# Altium schematic sheet CtDSP_IO.SchDoc
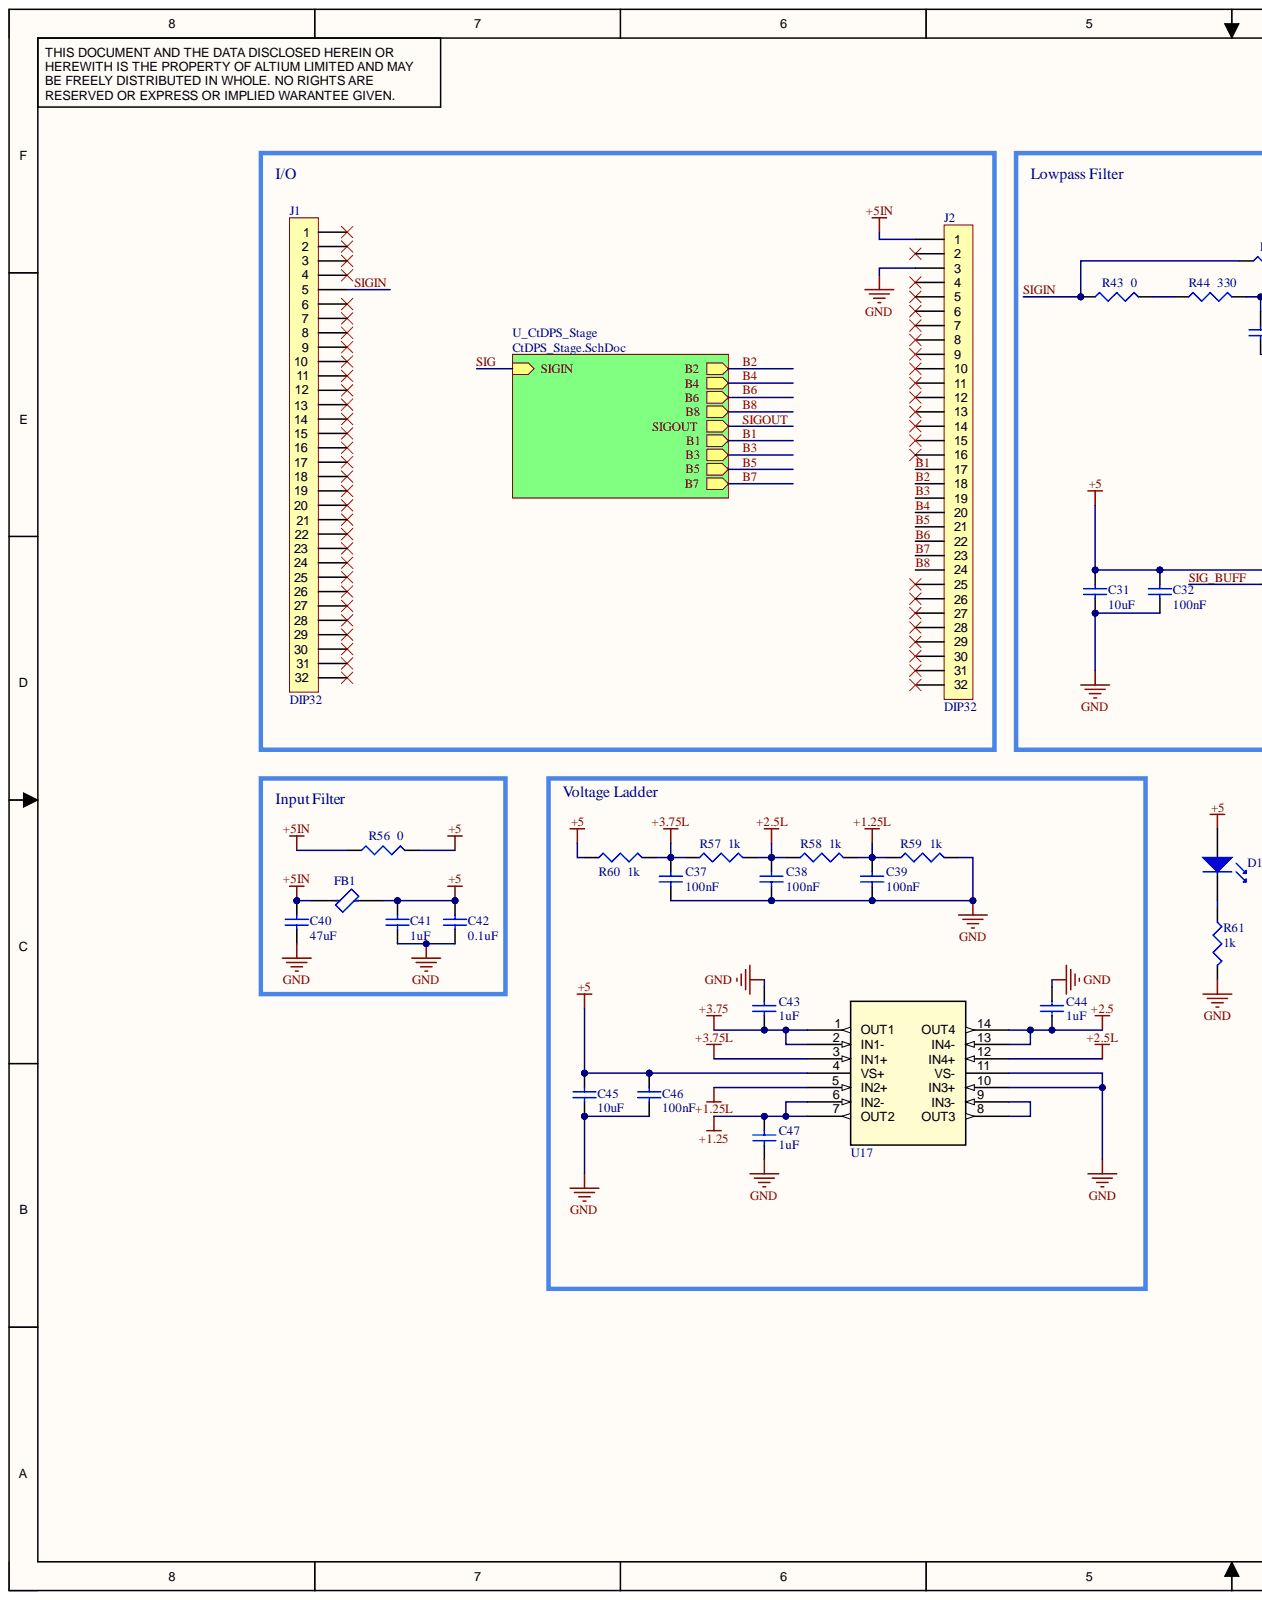
[diagram p0: CtDSP_IO.SchDoc, left]
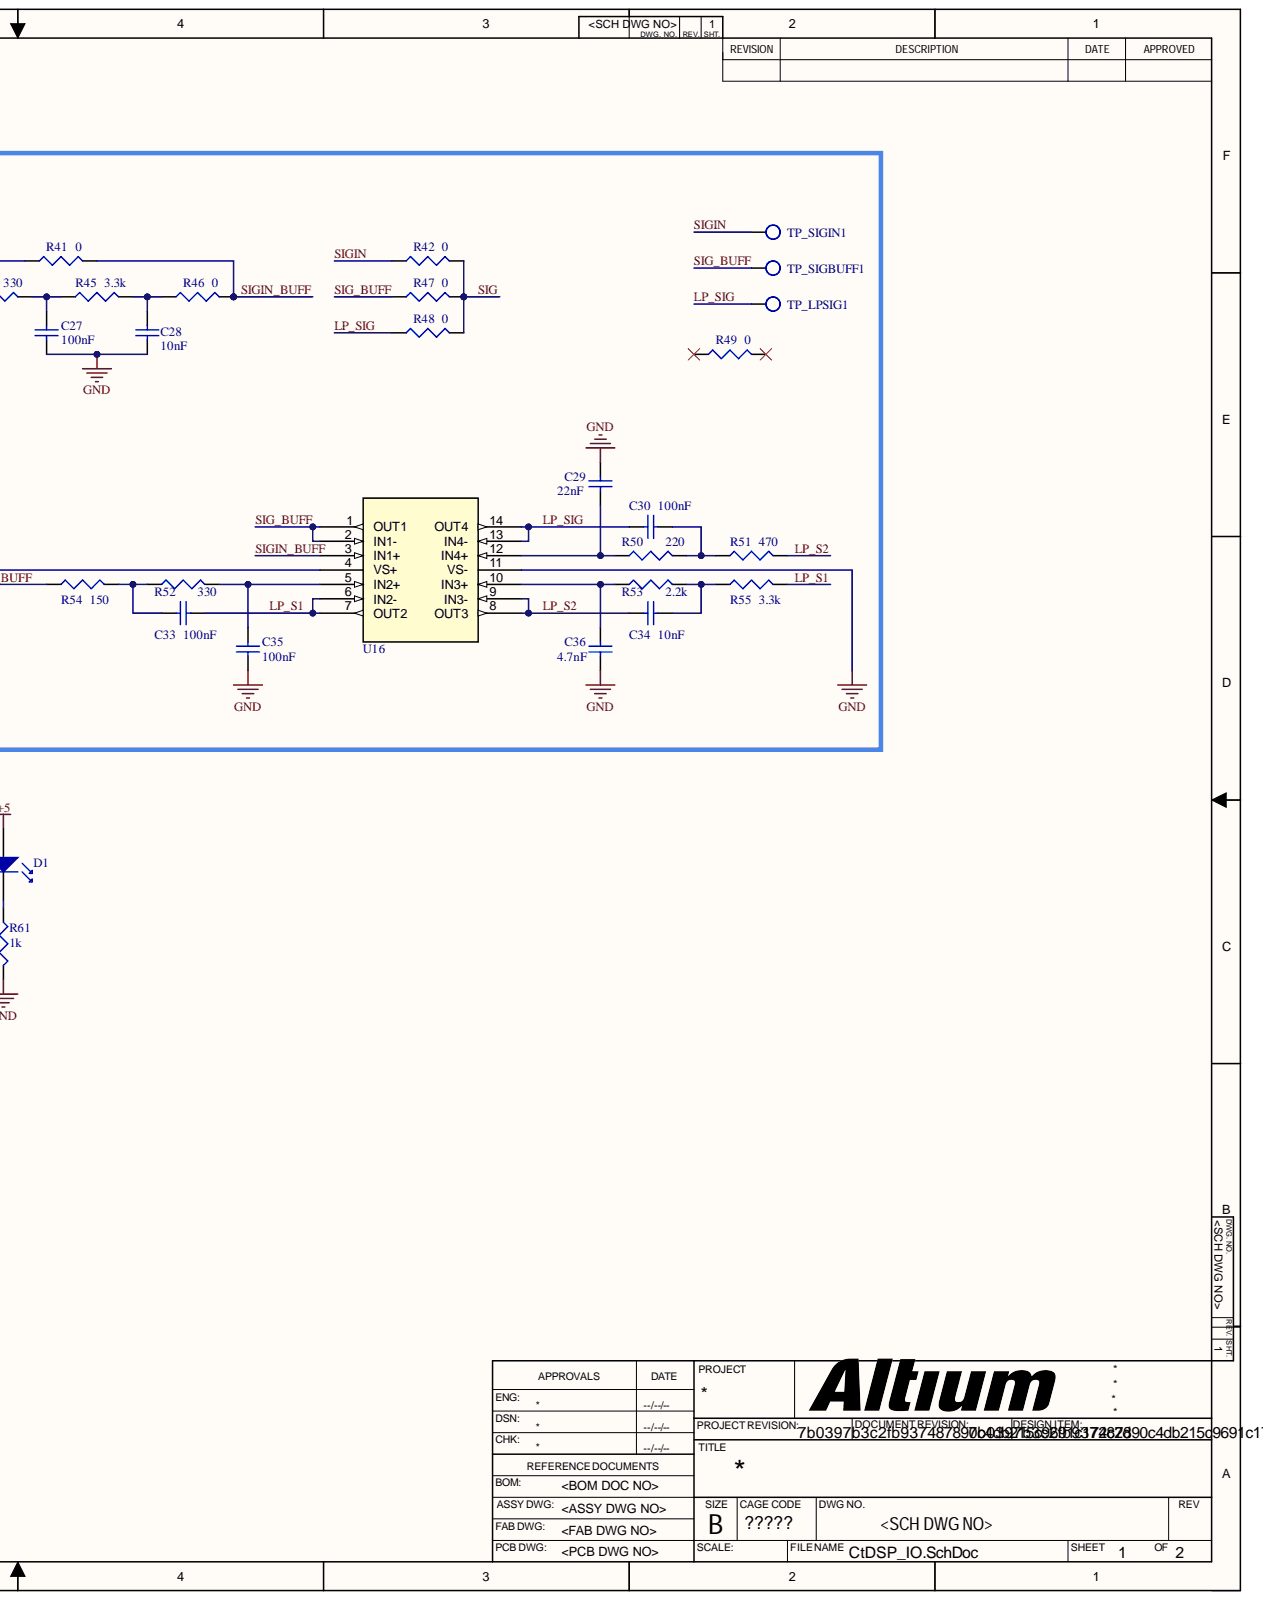
[diagram p1: CtDSP_IO.SchDoc, right]

## Parts
C27  100nF  [C_0402]
C28  10nF  [C_0402]
C29  22nF  [C_0402]
C30  100nF  [C_0402]
C31  10uF  [C_0402]
C32  100nF  [C_0402]
C33  100nF  [C_0402]
C34  10nF  [C_0402]
C35  100nF  [C_0402]
C36  4.7nF  [C_0402]
C37  100nF  [C_0402]
C38  100nF  [C_0402]
C39  100nF  [C_0402]
C40  47uF  [C_0805]
C41  1uF  [C_0603]
C42  0.1uF  [C_0402]
C43  1uF  [C_0603]
C44  1uF  [C_0603]
C45  10uF  [C_0402]
C46  100nF  [C_0402]
C47  1uF  [C_0603]
D1  LED_0603  [LED_6003]  (value not drawn)
FB1  BLM18PG121SN1D  [BLM18PG121SN1D]  (value not drawn)
J1  DIP32  [DIP32]
J2  DIP32  [DIP32]
R41  0  [RES_1206]
R42  0  [RES_1206]
R43  0  [RES_1206]
R44  330  [RES_0603]
R45  3.3k  [RES_0603]
R46  0  [RES_1206]
R47  0  [RES_1206]
R48  0  [RES_1206]
R49  0  [RES_1206]
R50  220  [RES_0402]
R51  470  [RES_0402]
R52  330  [RES_0603]
R53  2.2k  [RES_0603]
R54  150  [RES_0603]
R55  3.3k  [RES_0603]
R56  0  [RES_1206]
R57  1k  [RES_0603]
R58  1k  [RES_0603]
R59  1k  [RES_0603]
R60  1k  [RES_0603]
R61  1k  [RES_0402]
TP_LPSIG1  TESTPOINT  [TESTPOINT]  (value not drawn)
TP_SIGBUFF1  TESTPOINT  [TESTPOINT]  (value not drawn)
TP_SIGIN1  TESTPOINT  [TESTPOINT]  (value not drawn)
U16  ADA4891-4ARZ-R7  [ADA4891-4ARZ-R7]  (value not drawn)
U17  ADA4891-4ARZ-R7  [ADA4891-4ARZ-R7]  (value not drawn)

## Nets
+1.25: C47.2 U17.6(IN2-) U17.7(OUT2)
+1.25L: C39.2 R58.2 R59.1 U17.5(IN2+)
+2.5: C44.1 U17.13(IN4-) U17.14(OUT4)
+2.5L: C38.2 R57.2 R58.1 U17.12(IN4+)
+3.75: C43.1 U17.1(OUT1) U17.2(IN1-)
+3.75L: C37.2 R57.1 R60.2 U17.3(IN1+)
+5: C31.1 C32.2 C41.2 C42.1 C45.1 C46.2 D1.2 FB1.2 R56.2 R60.1 U16.4(VS+) U17.4(VS+)
+5IN: C40.2 FB1.1 J2.1 R56.1
GND: C27.1 C28.1 C29.2 C31.2 C32.1 C35.1 C36.1 C37.1 C38.1 C39.1 C40.1 C41.1 C42.2 C43.2 C44.2 C45.2 C46.1 C47.1 J2.3 R59.2 R61.2 U16.11(VS-) U17.10(IN3+) U17.11(VS-)
B1: J2.17
B2: J2.18
B3: J2.19
B4: J2.20
B5: J2.21
B6: J2.22
B7: J2.23
B8: J2.24
LP_S1: C33.1 R55.2 U16.6(IN2-) U16.7(OUT2)
LP_S2: C34.1 R51.2 U16.8(OUT3) U16.9(IN3-)
LP_SIG: C30.2 R48.1 TP_LPSIG1.1 U16.13(IN4-) U16.14(OUT4)
SIG: R42.2 R47.2 R48.2
SIG_BUFF: R47.1 R54.1 TP_SIGBUFF1.1 U16.1(OUT1) U16.2(IN1-)
SIGIN: J1.5 R41.1 R42.1 R43.1 TP_SIGIN1.1
SIGIN_BUFF: R41.2 R46.2 U16.3(IN1+)
NetC27_2: C27.2 R44.2 R45.1
NetC28_2: C28.2 R45.2 R46.1
NetC29_1: C29.1 R50.1 U16.12(IN4+)
NetC30_1: C30.1 R50.2 R51.1
NetC33_2: C33.2 R52.1 R54.2
NetC34_2: C34.2 R53.2 R55.1
NetC35_2: C35.2 R52.2 U16.5(IN2+)
NetC36_2: C36.2 R53.1 U16.10(IN3+)
NetD1_1: D1.1 R61.1
NetR43_2: R43.2 R44.1
NetU17_8: U17.8(OUT3) U17.9(IN3-)
SIGOUT:

Not connected: J1 1 2 3 4 6 7 8 9 10 11 12 13 14 15 16 17 18 19 20 21 22 23 24 25 26 27 28 29 30 31 32; J2 2 4 5 6 7 8 9 10 11 12 13 14 15 16 25 26 27 28 29 30 31 32; R49 1 2

## Library data of the parts
C27-C39 C42 C45 C46 = C_0402: Component Kind: Standard; Library Name: ctdsp.SchLib
  C27 C30 C32 C33 C35 C37-C39 C42 C46: LCSC Part #: C1525
  C28 C34: LCSC Part #: C15195
  C29: LCSC Part #: C1532
  C31 C45: LCSC Part #: C15525
  C36: LCSC Part #: C1538
C40 = C_0805: Component Kind: Standard; LCSC Part #: C16780; Library Name: ctdsp.SchLib
C41 C43 C44 C47 = C_0603: Component Kind: Standard; LCSC Part #: C15849; Library Name: ctdsp.SchLib
FB1 = BLM18PG121SN1D: -55℃~+125℃ 1 120Ω@100MHz 2A 50mΩ ±25% 0603 Ferrite Beads ROHS; Component Kind: Standard; LCSC Part #: C14709; Library Name: ctdsp.SchLib
R41-R43 R46-R49 R56 = RES_1206: Component Kind: Standard; LCSC Part #: C17888; Library Name: ctdsp.SchLib
R44 R45 R52-R55 R57-R60 = RES_0603: Component Kind: Standard; Library Name: ctdsp.SchLib
  R44 R52: LCSC Part #: C23138
  R45 R55: LCSC Part #: C22978
  R53: LCSC Part #: C4190
  R54: LCSC Part #: C22808
  R57-R60: LCSC Part #: C5123612
R50 R51 R61 = RES_0402: Component Kind: Standard; Library Name: ctdsp.SchLib
  R50: LCSC Part #: C25091
  R51: LCSC Part #: C25117
  R61: LCSC Part #: C11702
U16 U17 = ADA4891-4ARZ-R7: Quad Rail-to-Rail Op-Amp, 240 MHz, 170 V/µs, SOT-23-5; Component Kind: Standard; LCSC Part #: C654290; Library Name: ctdsp.SchLib
D1 = LED_0603: Component Kind: Standard; LCSC Part #: C2286; Library Name: ctdsp.SchLib
J1 J2 = DIP32: Component Kind: Standard; Library Name: ctdsp.SchLib
TP_LPSIG1 TP_SIGBUFF1 TP_SIGIN1 = TESTPOINT: Component Kind: Standard; Library Name: ctdsp.SchLib
